# Altium schematic sheet BLE.SchDoc(BLE)
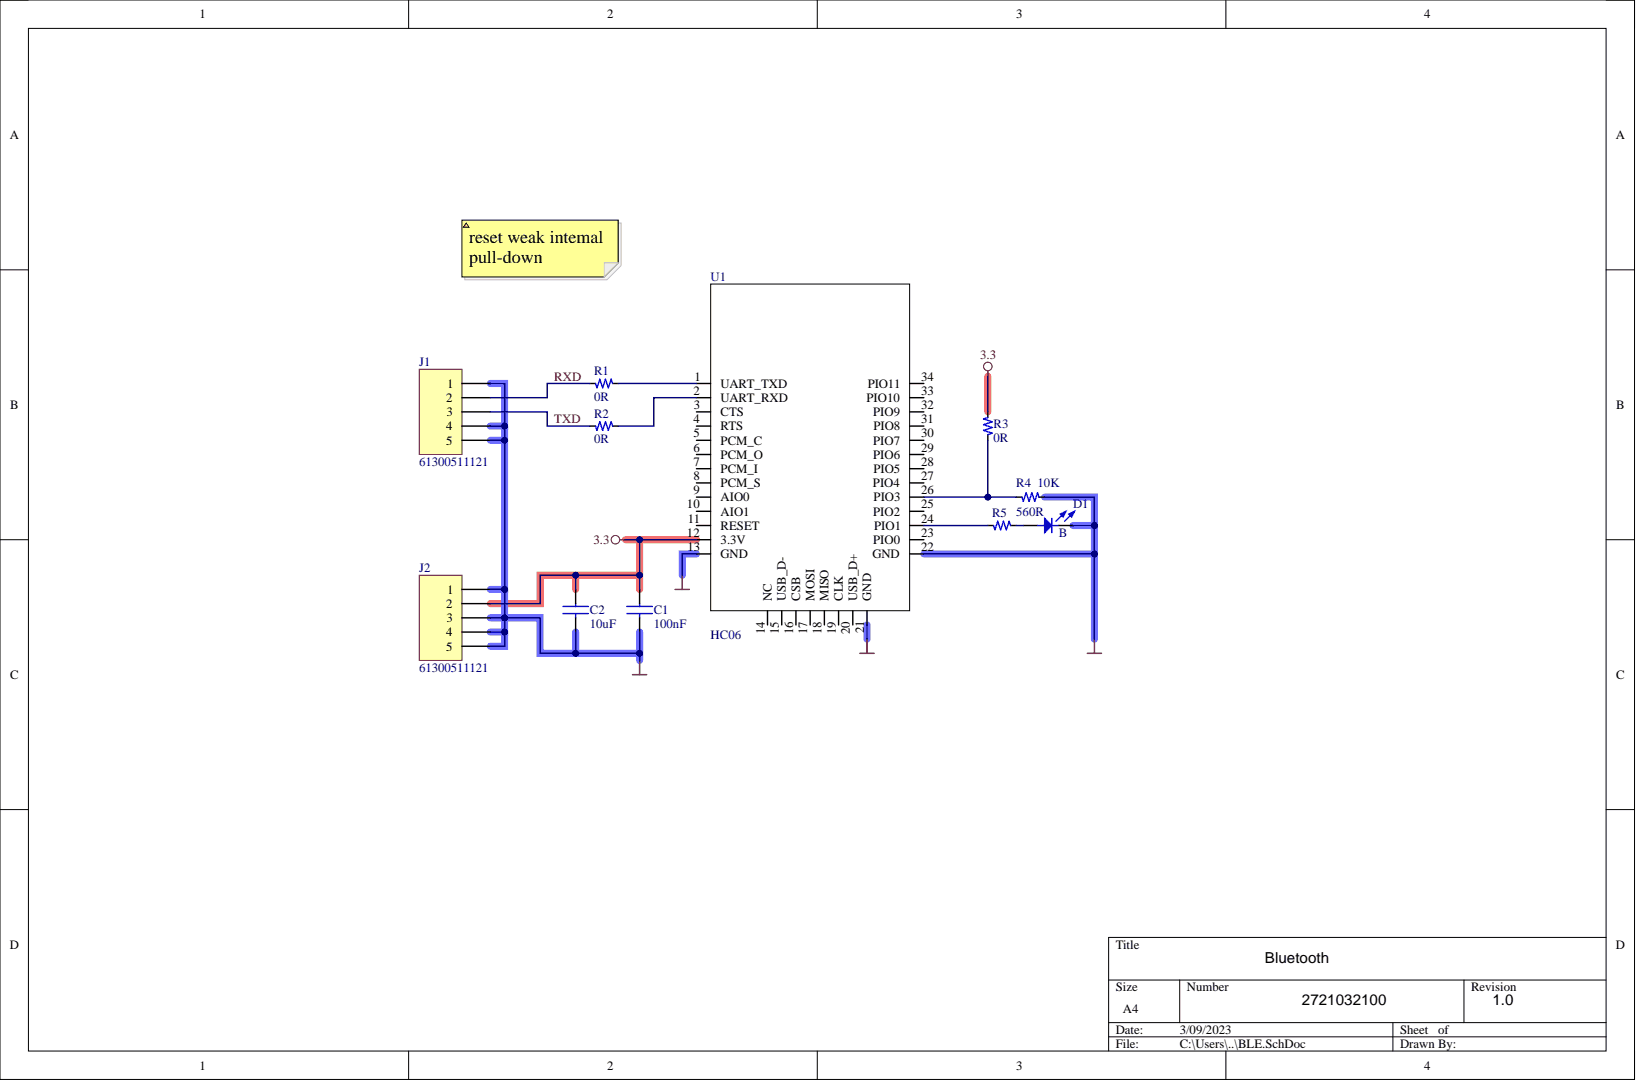
[diagram p0: BLE.SchDoc]

## Parts
C1  100nF  [RC0402_1]
C2  10uF  [RC0603_1]
D1  B  [LED_SMD_0603_BLUE]
J1  61300511121  [CON_TH_5PIN_2.54P_61300511121_WE]
J2  61300511121  [CON_TH_5PIN_2.54P_61300511121_WE]
R1  0R  [RC0402_1]
R2  0R  [RC0402_1]
R3  0R  [RC0402_1]
R4  10K  [RC0603_1]
R5  560R  [RC0603_1]
U1  HC06  [MODULO_HC06]

## Nets
3.3: C1.1 C2.1 J2.2 R3.2 U1.12(3.3V)
GND: C1.2 C2.2 D1.CATHODE J1.1 J1.4 J1.5 J2.1 J2.3 J2.4 J2.5 R4.2 U1.13(GND) U1.21(GND) U1.22(GND)
RXD: J1.2 R1.1
TXD: J1.3 R2.1
NetD1_ANODE: D1.ANODE R5.2
NetR1_2: R1.2 U1.1(UART_TXD)
NetR2_2: R2.2 U1.2(UART_RXD)
NetR3_1: R3.1 R4.1 U1.26(PIO3)
NetR5_1: R5.1 U1.24(PIO1)

Not connected: U1 3(CTS) 4(RTS) 5(PCM_C) 6(PCM_O) 7(PCM_I) 8(PCM_S) 9(AIO0) 10(AIO1) 11(RESET) 14(NC) 15(USB_D-) 16(CSB) 17(MOSI) 18(MISO) 19(CLK) 20(USB_D+) 23(PIO0) 25(PIO2) 27(PIO4) 28(PIO5) 29(PIO6) 30(PIO7) 31(PIO8) 32(PIO9) 33(PIO10) 34(PIO11)

## Library data of the parts
C1 = GRM155R71C104KA88D: CAP, CERAMICA, 100NF, 16V, X7R, 0402; Manufacturer: MURATA; Order c: 8819742; upBCE: 0.01; Value: 0.1UF; Case: 0402; Component Kind: Standard; Library Name: mylibA.SchLib; Manufacturer n: GRM155R71C104KA88D
C2 = GRM188R61A106ME69D: CAP, MLCC, X5R, 10UF, 10V, X5R, 0603; Manufacturer: MURATA; Order c: 81-GRM188R61A106ME9D; upBCE: 0.03; Case: 0603; Component Kind: Standard; Library Name: mylibA.SchLib; Manufacturer n: GRM188R61A106ME69D
D1 = LED_BLUE_0603: LED, 0603, BLUE, 180MCD, 470NM; Manufacturer: KINGBRIGHT; Manufacturer n: APT1608VBC/D; Order c: 604-APT1608VBC/D; upBCE: 0.141; Case: SMD; Component Kind: Standard; Library Name: mylibA.SchLib; Value: BLUE
J1 J2 = Header 5: Header, 5-Pin; Component Kind: Standard; LatestRevisionDate: 17-Jul-2002; LatestRevisionNote: Re-released for DXP Platform.; Library Name: Miscellaneous Connectors.IntLib; Signal Integrity: Connector; Publisher: Altium Limited
R1-R3 = CRCW04020000Z0ED: RESISTORE, 0R, 0.1W, 1%, 0402, SMD; Manufacturer: Vishay Dralogic; Order c: 1469661; upBCE: 0.0006; Case: 0402; Component Kind: Standard; Library Name: mylibA.SchLib; Manufacturer n: CRCW04020000Z0ED; Value: 0R
R4 = CRCW060310K0FKEA: RESISTORE, 10K, 0.1W, 1%, 0603, SMD; Manufacturer: Vishay Dralogic; Order c: 1469748; upBCE: 0.00066; Case: 0603; Component Kind: Standard; Library Name: mylibA.SchLib; Manufacturer n: CRCW060310K0FKEA; Value: 10K
R5 = CRCW0603560RFKEA: RESISTORE, 560R, 0.1W, 1%, 0603, SMD; Manufacturer: Vishay Dralogic; Order c: 71-CRCW0603-560-E3; upBCE: 0.00066; Case: 0603; Component Kind: Standard; Library Name: mylibA.SchLib; Manufacturer n: CRCW0603560RFKEA; Value: 560R
U1 = BLUETOOTH_HC06: Modulo Bluetooth/802.15.1 Class2; Manufacturer: WAVESEN; Case: SMD; Component Kind: Standard; Library Name: mylibA.SchLib; Manufacturer n: HC06; Value: HC06
